# Altium schematic sheet VGA_ADAPTER.schdoc
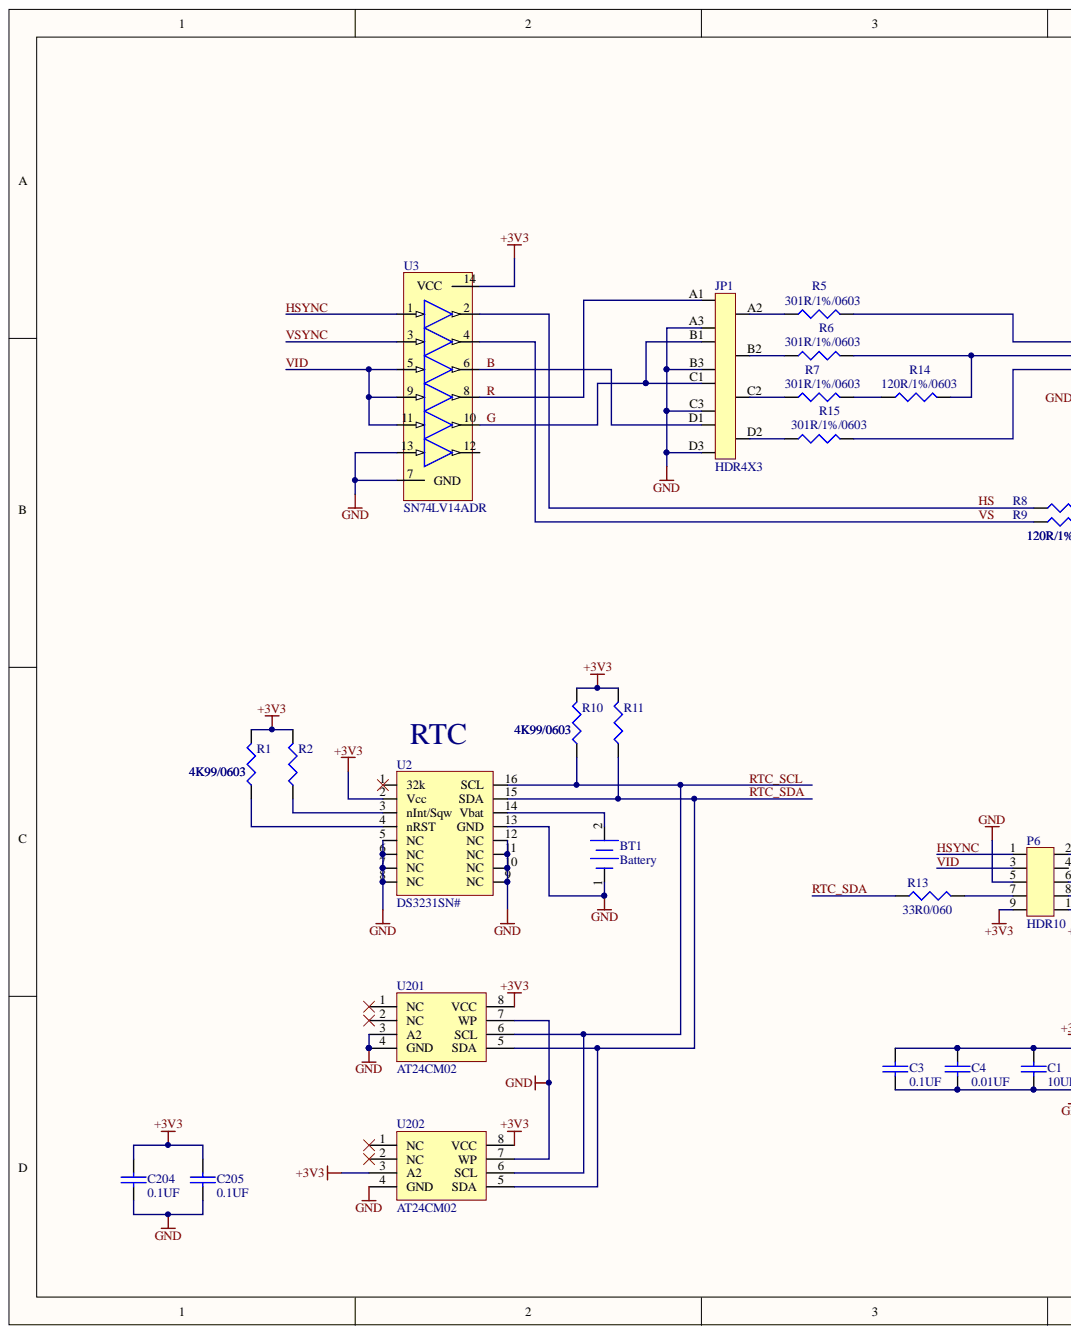
[diagram p0: VGA_ADAPTER.schdoc, left]
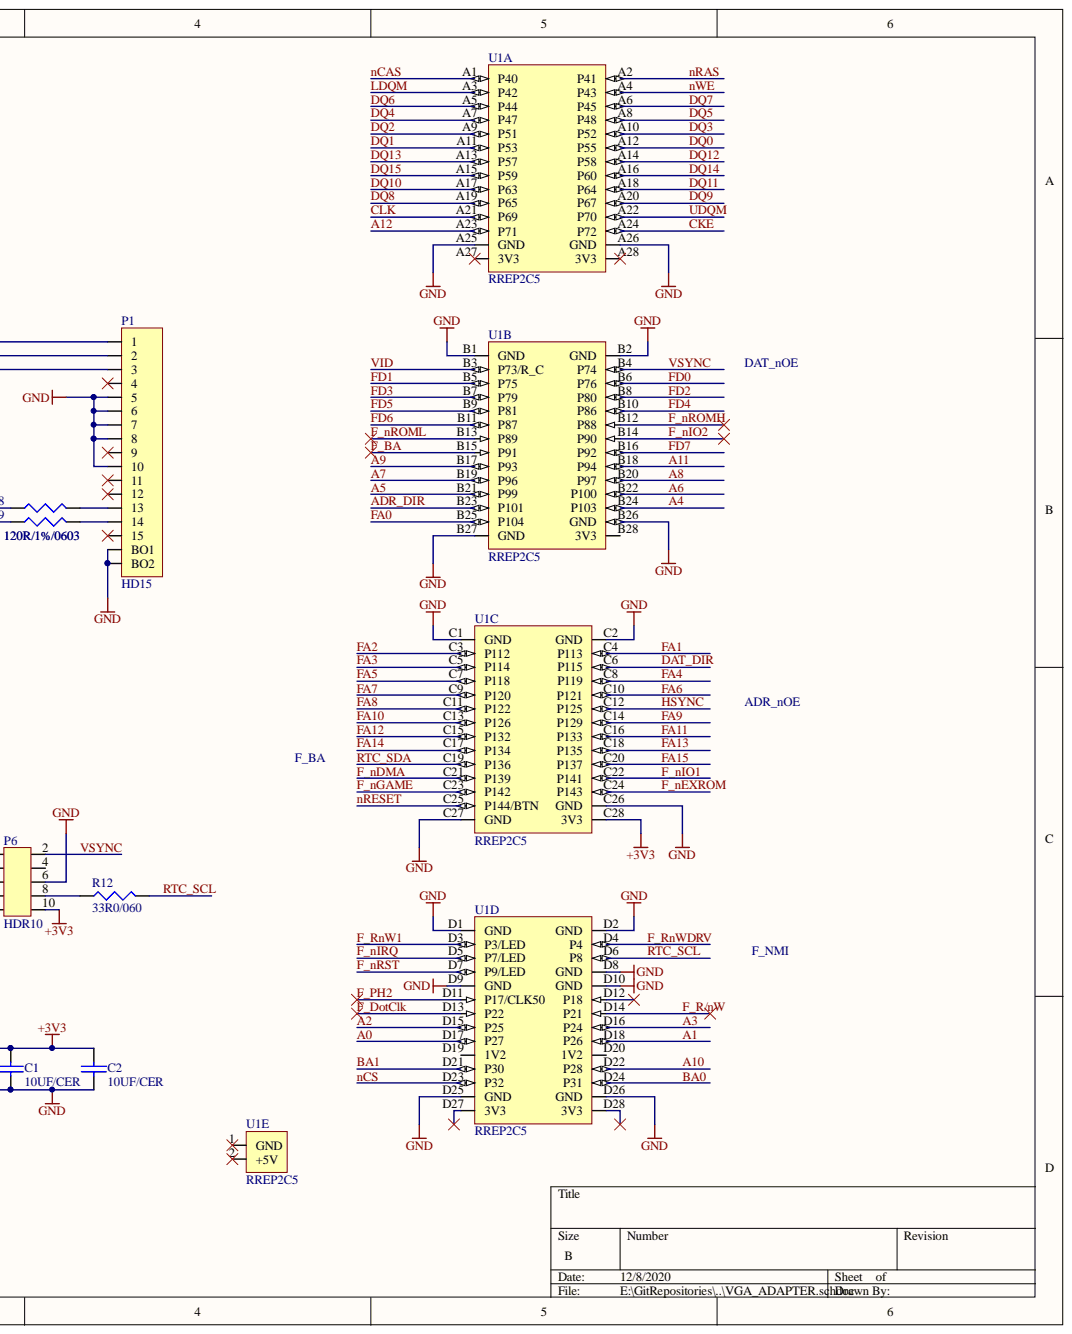
[diagram p1: VGA_ADAPTER.schdoc, right]

## Parts
BT1  Battery  [BC501SM]
C1  10UF/CER  [0805]
C2  10UF/CER  [0805]
C3  0.1UF  [0603]
C4  0.01UF  [0603]
C204  0.1UF  [0603]
C205  0.1UF  [0603]
JP1  HDR4X3  [HDR4X3]
P1  HD15  [DB15FLC]
P6  HDR10  [HDR10]
R1  4K99/0603  [0603]
R2  4K99/0603  [0603]
R5  301R/1%/0603  [0603]
R6  301R/1%/0603  [0603]
R7  301R/1%/0603  [0603]
R8  120R/1%/0603  [0603]
R9  120R/1%/0603  [0603]
R10  4K99/0603  [0603]
R11  4K99/0603  [0603]
R12  33R0/060  [0603]
R13  33R0/060  [0603]
R14  120R/1%/0603  [0603]
R15  301R/1%/0603  [0603]
U1  RREP2C5  [RR2C5]  (parts A,B,C,D,E)
U2  DS3231SN#  [SOL16]
U3  SN74LV14ADR  [SOP14]
U201  AT24CM02  [SO-8]
U202  AT24CM02  [SO-8]

## Nets
+3V3: C1.2 C2.2 C3.1 C4.1 C204.1 C205.1 P6.9 P6.10 R1.2 R2.2 R10.2 R11.2 U1.C28(3V3) U2.2(Vcc) U3.14(VCC) U201.8(VCC) U202.3(A2) U202.8(VCC)
GND: BT1.1 C1.1 C2.1 C3.2 C4.2 C204.2 C205.2 JP1.A3 JP1.B3 JP1.C3 JP1.D3 P1.5 P1.6 P1.7 P1.8 P1.10 P1.BO1 P1.BO2 P6.5 P6.6 U1.A25(GND) U1.A26(GND) U1.B1(GND) U1.B2(GND) U1.B26(GND) U1.B27(GND) U1.C1(GND) U1.C2(GND) U1.C26(GND) U1.C27(GND) U1.D1(GND) U1.D2(GND) U1.D8(GND) U1.D9(GND) U1.D10(GND) U1.D25(GND) U1.D26(GND) U2.5(NC) U2.6(NC) U2.7(NC) U2.8(NC) U2.9(NC) U2.10(NC) U2.11(NC) U2.12(NC) U2.13(GND) U3.7(GND) U3.13 U201.3(A2) U201.4(GND) U201.7(WP) U202.4(GND) U202.7(WP)
A0: U1.D17(P27)
A1: U1.D18(P26)
A2: U1.D15(P25)
A3: U1.D16(P24)
A4: U1.B24(P103)
A5: U1.B21(P99)
A6: U1.B22(P100)
A7: U1.B19(P96)
A8: U1.B20(P97)
A9: U1.B17(P93)
A10: U1.D22(P28)
A11: U1.B18(P94)
A12: U1.A23(P71)
ADR_DIR: U1.B23(P101)
B: JP1.D1 U3.6
BA0: U1.D24(P31)
BA1: U1.D21(P30)
CKE: U1.A24(P72)
CLK: U1.A21(P69)
DAT_DIR: U1.C6(P115)
DQ0: U1.A12(P55)
DQ1: U1.A11(P53)
DQ2: U1.A9(P51)
DQ3: U1.A10(P52)
DQ4: U1.A7(P47)
DQ5: U1.A8(P48)
DQ6: U1.A5(P44)
DQ7: U1.A6(P45)
DQ8: U1.A19(P65)
DQ9: U1.A20(P67)
DQ10: U1.A17(P63)
DQ11: U1.A18(P64)
DQ12: U1.A14(P58)
DQ13: U1.A13(P57)
DQ14: U1.A16(P60)
DQ15: U1.A15(P59)
F_BA: U1.B15(P91)
F_DOTCLK: U1.D13(P22)
F_NDMA: U1.C21(P139)
F_NEXROM: U1.C24(P143)
F_NGAME: U1.C23(P142)
F_NIO1: U1.C22(P141)
F_NIO2: U1.B14(P90)
F_NIRQ: U1.D5(P7/LED)
F_NROMH: U1.B12(P88)
F_NROML: U1.B13(P89)
F_NRST: U1.D7(P9/LED)
F_PH2: U1.D11(P17/CLK50)
F_R/NW: U1.D14(P21)
F_RNW1: U1.D3(P3/LED)
F_RNWDRV: U1.D4(P4)
FA0: U1.B25(P104)
FA1: U1.C4(P113)
FA2: U1.C3(P112)
FA3: U1.C5(P114)
FA4: U1.C8(P119)
FA5: U1.C7(P118)
FA6: U1.C10(P121)
FA7: U1.C9(P120)
FA8: U1.C11(P122)
FA9: U1.C14(P129)
FA10: U1.C13(P126)
FA11: U1.C16(P133)
FA12: U1.C15(P132)
FA13: U1.C18(P135)
FA14: U1.C17(P134)
FA15: U1.C20(P137)
FD0: U1.B6(P76)
FD1: U1.B5(P75)
FD2: U1.B8(P80)
FD3: U1.B7(P79)
FD4: U1.B10(P86)
FD5: U1.B9(P81)
FD6: U1.B11(P87)
FD7: U1.B16(P92)
G: JP1.B1 JP1.C1 U3.10
HS: R8.1 U3.2
HSYNC: P6.1 U1.C12(P125) U3.1
LDQM: U1.A3(P42)
NCAS: U1.A1(P40)
NCS: U1.D23(P32)
NRAS: U1.A2(P41)
NRESET: U1.C25(P144/BTN)
NWE: U1.A4(P43)
R: JP1.A1 U3.8
RTC_SCL: R10.1 R12.2 U1.D6(P8) U2.16(SCL) U201.6(SCL) U202.6(SCL)
RTC_SDA: R11.1 R13.1 U1.C19(P136) U2.15(SDA) U201.5(SDA) U202.5(SDA)
UDQM: U1.A22(P70)
VID: P6.3 U1.B3(P73/R_C) U3.5 U3.9 U3.11
VS: R9.1 U3.4
VSYNC: P6.2 U1.B4(P74) U3.3
NetBT1_2: BT1.2 U2.14(Vbat)
NetJP1_A2: JP1.A2 R5.1
NetJP1_B2: JP1.B2 R6.1
NetJP1_C2: JP1.C2 R7.1
NetJP1_D2: JP1.D2 R15.1
NetP1_1: P1.1 R5.2
NetP1_2: P1.2 R6.2 R14.2
NetP1_3: P1.3 R15.2
NetP1_13: P1.13 R8.2
NetP1_14: P1.14 R9.2
NetP6_7: P6.7 R13.2
NetP6_8: P6.8 R12.1
NetR1_1: R1.1 U2.4(nRST)
NetR2_1: R2.1 U2.3(nInt/Sqw)
NetR7_2: R7.2 R14.1

Not connected: P1 4 9 11 12 15; P6 4; U1 1(GND) 2(+5V) A27(3V3) A28(3V3) B28(3V3) D12(P18) D19(1V2) D20(1V2) D27(3V3) D28(3V3); U2 1(32k); U3 12; U201 1(NC) 2(NC); U202 1(NC) 2(NC)

## Library data of the parts
BT1 = BC501SM-TR: Battery Holder (Open) Coin, 12.0mm 1 Cell SMD (SMT) Tab; DK PN: BC501SM-TR-ND; MFG: MPD; Component Kind: Standard; Library Name: C64Interface.SchLib; MFG PN: BC501SM-TR; Price: 1.17
C1 C2 = 10UF/CER: 10µF ±10% 25V Ceramic Capacitor X7S 0805; Text Field1: Murata; Text Field2: GRM21BC71E106KE11L; Text Field3: 490-10496-1-ND; Component Kind: Standard; Library Name: C64Interface.SchLib
C3 C204 C205 = 0.1UF: CAP .10UF 16V CERAMIC X7R 0603; DK PN: 399-1096-1-ND; MFG: Kemet; MFG PN: C0603C104K4RACTU; Component Kind: Standard; Library Name: C64Interface.SchLib; Price: 1.17
C4 = 0.01UF: 10000pF ±10% 10V Ceramic Capacitor X7R 0603 (1608 Metric); DK PN: 399-11132-1-ND; MFG: Kemet; MFG PN: C0603C103K8RACTU; Component Kind: Standard; Library Name: C64Interface.SchLib; Price: 1.17
P1 = HD15: CONN DSUB HD RCPT 15POS R/A SLDR; Text Field1: Amphenol; Text Field2: ICD15S13E4GX00LF; Text Field3: 609-5181-ND; Component Kind: Standard; Library Name: C64Interface.SchLib
R1 R2 R10 R11 = 4K99/0603: RES 4.99K OHM 1/10W 1% 0603 SMD; DK PN: 541-3985-1-ND; MFG: Dale/Vishay; MFG PN: CRCW06034K99FKEAC; Component Kind: Standard; Library Name: C64Interface.SchLib; Price: 5.02
R5-R7 R15 = 301R/1%/0603: 301 Ohms ±1% 0.1W, 1/10W Chip Resistor 0603 (1608 Metric) Moisture Resistant Thick Film; DK PN: 311-301HRCT-ND; MFG: Yeago; MFG PN: RC0603FR-07301RL; Component Kind: Standard; Library Name: C64Interface.SchLib
R8 R9 R14 = 120R/1%/0603: 120 Ohms ±1% 0.1W, 1/10W Chip Resistor 0603 (1608 Metric) Moisture Resistant Thick Film; DK PN: 311-120HRCT-ND; MFG: Yaego; MFG PN: RC0603FR-07120RL; Component Kind: Standard; Library Name: C64Interface.SchLib
R12 R13 = 33R0/060: 33 Ohms ±1% 0.1W, 1/10W Chip Resistor 0603 (1608 Metric) Moisture Resistant Thick Film; DK PN: 311-33.0HRCT-ND; MFG: Yaego; MFG PN: RC0603FR-0733RL; Component Kind: Standard; Library Name: C64Interface.SchLib
U1 = RREP2C5: RioRand EP2C5T144 Altera Cyclone II FPGA Mini Development Board; Component Kind: Standard; Library Name: C64Interface.SchLib
U2 = DS3231SN#: Real Time Clock (RTC) IC Clock/Calendar I²C, 2-Wire Serial 16-SOIC (0.295", 7.50mm Width); DK PN: DS3231SN#-ND; MFG: Maxim; Component Kind: Standard; Library Name: C64Interface.SchLib; MFG PN: DS3231SN#; Price: 9.79
U3 = SN74LV14ADR: Inverter IC 6 Channel Schmitt Trigger 14-SOIC; DK PN: 296-1678-1-ND; MFG: TI; Component Kind: Standard; Library Name: C64Interface.SchLib; MFG PN: SN74LV14ADR; Price: 0.41
U201 U202 = AT24CM02: EEPROM Memory IC 2Mb (256K x 8) I²C 1MHz 450ns 8-SOIC; DK PN: AT24CM02-SSHM-TCT-ND; MFG: Microchip; MFG PN: AT24CM02-SSHM-T; Component Kind: Standard; Library Name: C64Interface.SchLib; Price: 1.17
JP1 = HDR4X3: Component Kind: Standard; Library Name: C64Interface.SchLib
P6 = HDR10: Component Kind: Standard; Library Name: C64Interface.SchLib
